# Altium schematic sheet Battery Manager.SchDoc
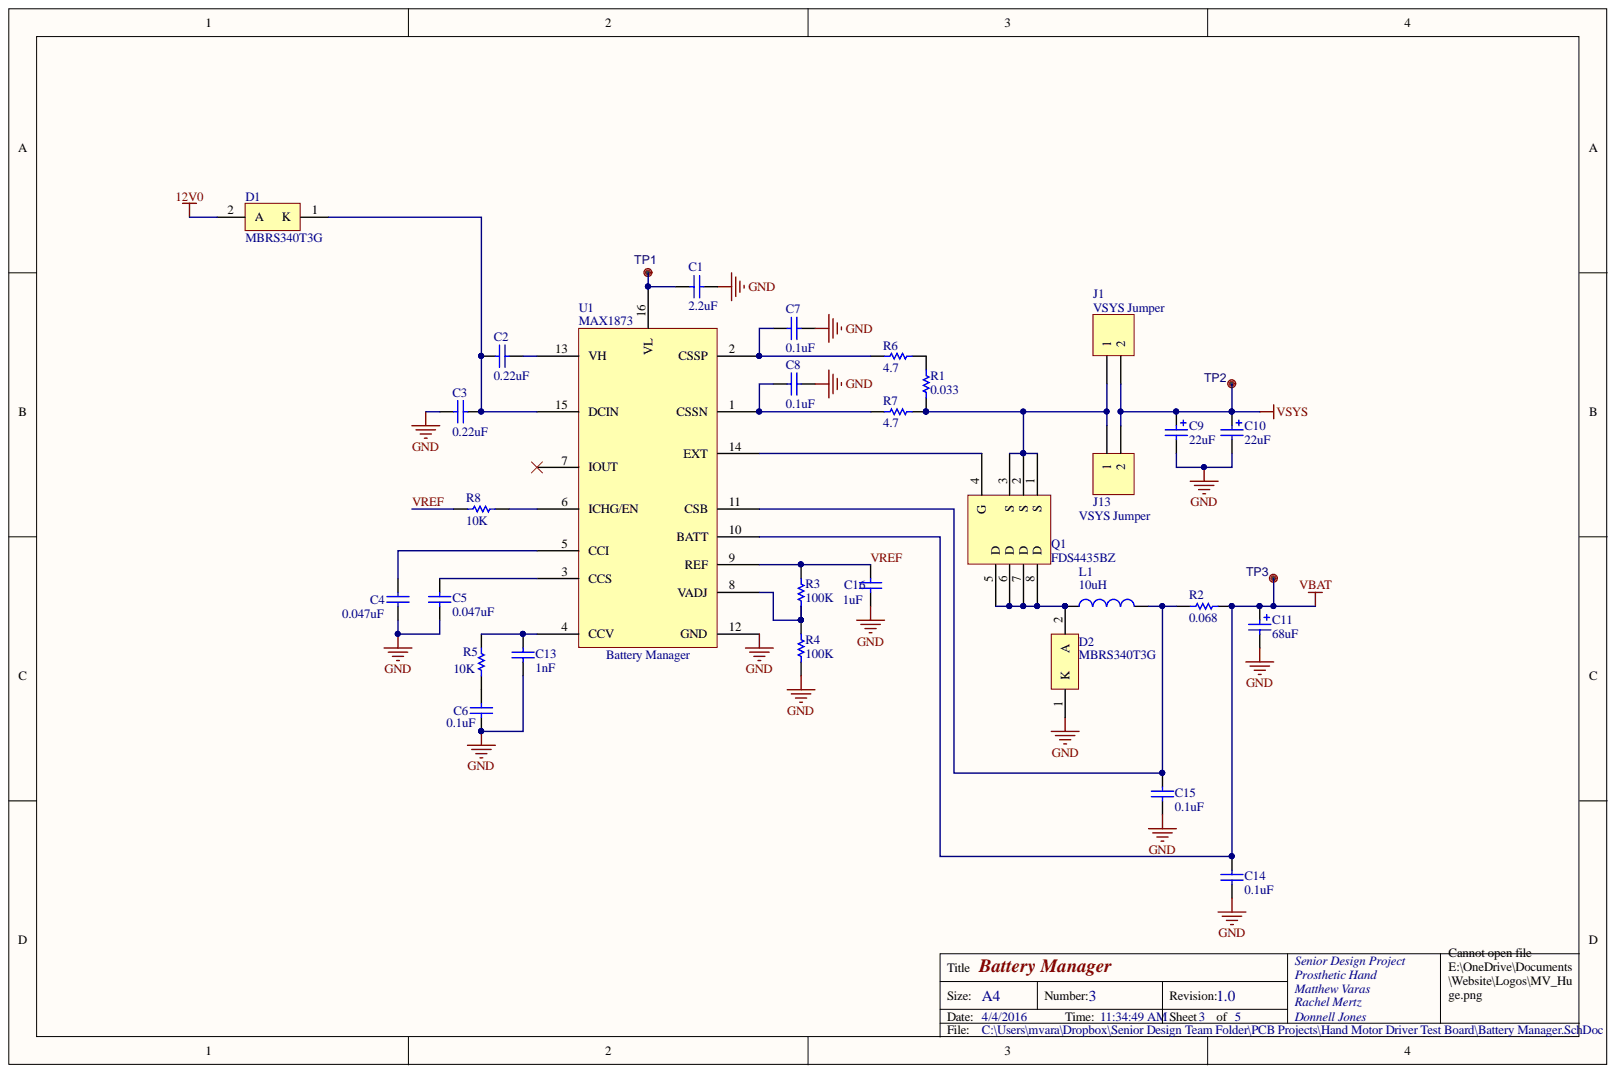
[diagram p0: Battery Manager.SchDoc]

## Parts
C1  2.2uF  [CAPC0805(2012)145_N]
C2  0.22uF  [CAPC0805(2012)145_N]
C3  0.22uF  [CAPC0805(2012)145_N]
C4  0.047uF  [CAPC0805(2012)75_N]
C5  0.047uF  [CAPC0805(2012)75_N]
C6  0.1uF  [CAPC0805(2012)145_N]
C7  0.1uF  [CAPC0805(2012)145_N]
C8  0.1uF  [CAPC0805(2012)145_N]
C9  22uF  [Cap Tant D]
C10  22uF  [Cap Tant D]
C11  68uF  [Cap Tant E]
C13  1nF  [CAPC0603(1608)100_N]
C14  0.1uF  [CAPC0805(2012)145_N]
C15  0.1uF  [CAPC0805(2012)145_N]
C16  1uF  [CAPC0805(2012)100_N]
D1  MBRS340T3G  [ONSC-SMC-2-403-03_V]
D2  MBRS340T3G  [ONSC-SMC-2-403-03_V]
J1  VSYS Jumper  [HDR1X2]
J13  VSYS Jumper  [HDR1X2]
L1  10uH  [SRR1260 Ind]
Q1  FDS4435BZ  [SOIC-8]
R1  0.033  [RESC2010(5025)_N]
R2  0.068  [WSL2816]
R3  100K  [RESC0805(2012)_N]
R4  100K  [RESC0805(2012)_N]
R5  10K  [RESC0805(2012)_N]
R6  4.7  [RESC0603(1608)_N]
R7  4.7  [RESC0603(1608)_N]
R8  10K  [RESC0805(2012)_N]
TP1  Test Point  [Testpoint_70]  (value not drawn)
TP2  Test Point  [Testpoint_70]  (value not drawn)
TP3  Test Point  [Testpoint_70]  (value not drawn)
U1  MAX1873  Desc: Battery Manager  [QSOP-16]

## Nets
12V0: D1.2
GND: C1.2 C3.2 C4.2 C5.2 C6.1 C7.1 C8.1 C9.2 C10.2 C11.2 C13.1 C14.2 C15.1 C16.1 D2.1 R4.1 U1.12(GND)
VBAT: C11.1 C14.1 R2.1 TP3.1 U1.10(BATT)
VSYS: C9.1 C10.1 J1.2 J13.2 TP2.1
VREF: C16.2 R3.2 R8.2 U1.9(REF)
NetC1_1: C1.1 TP1.1 U1.16(VL)
NetC2_1: C2.1 C3.1 D1.1 U1.15(DCIN)
NetC2_2: C2.2 U1.13(VH)
NetC4_1: C4.1 U1.5(CCI)
NetC5_1: C5.1 U1.3(CCS)
NetC6_2: C6.2 R5.2
NetC7_2: C7.2 R6.2 U1.2(CSSP)
NetC8_2: C8.2 R7.2 U1.1(CSSN)
NetC13_2: C13.2 R5.1 U1.4(CCV)
NetC15_2: C15.2 L1.2 R2.2 U1.11(CSB)
NetD2_2: D2.2 L1.1 Q1.5(D) Q1.6(D) Q1.7(D) Q1.8(D)
NetJ1_1: J1.1 J13.1 Q1.1(S) Q1.2(S) Q1.3(S) R1.1 R7.1
NetQ1_4: Q1.4(G) U1.14(EXT)
NetR1_2: R1.2 R6.1
NetR3_1: R3.1 R4.2 U1.8(VADJ)
NetR8_1: R8.1 U1.6(ICHG/EN)

Not connected: U1 7(IOUT)

## Library data of the parts
C1 = CMP-1036-04844-1: CAP CER 2.2UF 50V X5R 0805; Case-EIA: 0805; Case-Metric: 2012; DIGI_PN01: 445-14407-1-ND; MFG_NAME01: TDK; MFG_PN01: C2012X5R1H225K085AB; Tolerance: Â±10%; Component Kind: Standard; Library Name: Altium Content Vault; Max Thickness: 1.45 mm; Rated Voltage: 50 V; Technology: SMT; Value: 2.2uF
C2 C3 = CMP-1036-04552-1: CAP CER 0.22UF 35V X5R 0805; Case-EIA: 0805; Case-Metric: 2012; DIGI_PN01: 587-3366-1-ND; MFG_NAME01: Taiyo Yuden; MFG_PN01: GMK212BJ224KG; Rated Voltage: 50 V; Tolerance: Â±10%; Value: 220nF; Component Kind: Standard; Library Name: Altium Content Vault; Max Thickness: 1.45 mm; Technology: SMT
C4 C5 = CMP-1036-04259-1: CAP CER 0.047UF 50V X7R 0805; Case-EIA: 0805; Case-Metric: 2012; DIGI_PN01: 490-8050-1-ND; MFG_NAME01: Murata; MFG_PN01: GCM21BR71H473KA37L; Tolerance: Â±10%; Value: 47nF; Component Kind: Standard; Library Name: Altium Content Vault; Max Thickness: 0.75 mm; Rated Voltage: 50 V; Technology: SMT
C6-C8 C14 C15 = CMP-1036-04419-1: CAP CER 0.1UF 50V X7R 0805; Case-EIA: 0805; Case-Metric: 2012; DIGI_PN01: 445-6045-1-ND; MFG_NAME01: TDK; MFG_PN01: C2012X7R1H104K125AM; Tolerance: Â±10%; Value: 100nF; Component Kind: Standard; Library Name: Altium Content Vault; Max Thickness: 1.45 mm; Rated Voltage: 50 V; Technology: SMT
C9 C10 = Cap Pol2: CAP TANT 22UF 35V 10% 2917; Case-EIA: 2917; DIGI_PN01: 478-3356-1-ND; MFG_NAME01: AVX; MFG_PN01: TPSD226K035R0200; Component Kind: Standard; Library Name: Miscellaneous Devices.SchLib; Signal Integrity: Cap Pol; Simulation: CAP; Value: 22uF
C11 = Cap Pol2: CAP TANT 68UF 25V 20% 2917; Case-EIA: 2917; DIGI_PN01: 478-4152-1-ND; MFG_NAME01: AVX; MFG_PN01: TPSE686M025R0125; Component Kind: Standard; Library Name: Miscellaneous Devices.SchLib; Signal Integrity: Cap Pol; Simulation: CAP; Value: 68uF
C13 = CMP-1035-01889-1: CAP CER 1000PF 50V X7R 0603; Case-EIA: 0603; Case-Metric: 1608; DIGI_PN01: 490-1494-1-ND; MFG_NAME01: Murata; MFG_PN01: GRM188R71H102KA01D; Tolerance: Â±10%; Component Kind: Standard; Library Name: Altium Content Vault; Max Thickness: 1 mm; Rated Voltage: 50 V; Technology: SMT; Value: 1nF
C16 = CMP-1036-04737-1: CAP CER 1UF 25V X7R 0805; Case-EIA: 0805; Case-Metric: 2012; DIGI_PN01: 445-1354-1-ND; MFG_NAME01: TDK; MFG_PN01: C2012X7R1E105K125AB; Rated Voltage: 10 V; Tolerance: Â±10%; Component Kind: Standard; Library Name: Altium Content Vault; Max Thickness: 1 mm; Technology: SMT; Value: 1uF
D1 D2 = CMP-1055-00380-1: DIODE SCHOTTKY 40V 4A SMC; Manufacturer: On Semiconductor; Case-EIA: DO-214AB SMC; Configuration: Single; DIGI_PN01: MBRS340T3GOSCT-ND; IFSM Max (A): 80; Packing: Tape and Reel; Component Kind: Standard; ComponentLink1Description: Manufacturer URL; ComponentLink1URL: http://www.onsemi.com/; ComponentLink2Description: Datasheet; ComponentLink2URL: http://www.onsemi.cn/pub_link/Collateral/MBRS340T3-D.PDF; ComponentLink3Description: Package Specification; ComponentLink3URL: http://www.onsemi.com/pub_link/Collateral/403-03.PDF; DatasheetVersion: Rev. 11; Library Name: Altium Content Vault; MFG_NAME01: ON Semiconductor; MFG_PN01: MBRS340T3G; Mounting Technology: Surface Mount; PackageDescription: 2-Pin Small Molded Package, J-Bend Lead, Body 5.845 x 6.855 mm; PackageReference: SMC-2-403-03; PackageVersion: Rev. E, 08/2005; PartNumber: MBRS340T3G; RoHS: TRUE
J1 J13 = Header 2: CONN HEADER .100 SINGL STR 2POS; DIGI_PN01: S1012E-02-ND; MFG_NAME01: Sullins Connector Solutions; MFG_PN01: PEC02SAAN; Component Kind: Standard; LatestRevisionDate: 17-Jul-2002; LatestRevisionNote: Re-released for DXP Platform.; Library Name: Miscellaneous Connectors.SchLib; Signal Integrity: Connector; Publisher: Altium Limited
L1 = SRR1260A-100MCT-ND: FIXED IND 10UH 5.5A 20 MOHM SMD; MFG_NAME01: Bourns; Component Kind: Standard; Current Rating: 5.5A; DIGI_PN01: SRR1260A-100MCT-ND; MFG_PN01: SRR1260A-100M; Signal Integrity: Inductor; Simulation: INDUCTOR; Value: 10uH; Library Name: Prosthetic Hand Schematic Lib.SchLib
Q1 = FDS4435BZ: MOSFET P-CH 30V 8.8A 8-SOIC; DIGI_PN01: FDS4435BZCT-ND; MFG_NAME01: Fairchild Semiconductor; Case-EIA: 8-SOIC; Component Kind: Standard; MFG_PN01: FDS4435BZ; Library Name: Prosthetic Hand Schematic Lib.SchLib
R1 = CMP-1017-00002-1: RES SMD 0.033 OHM 1% 1W 2010; Case-EIA: 2010; Case-Metric: 5025; DIGI_PN01: WSLF-.033CT-ND; MFG_NAME01: Murata; MFG_PN01: WSL2010R0330FEA18; Component Kind: Standard; Library Name: Altium Content Vault; Power: 1W; Technology: SMT; Tolerance: 1%; Value: 0.033
R2 = CMP-1017-00002-1: RES SMD 0.068 OHM 1% 2W 2816; Case-EIA: 2816; Case-Metric: 5025; DIGI_PN01: WSLK-.068CT-ND; MFG_NAME01: Vishay Dale; MFG_PN01: WSL2816R0680FEH; Component Kind: Standard; Power: 2W; Technology: SMT; Tolerance: 1%; Value: 0.068
R3 R4 = CMP-1013-00736-1: RES SMD 100K OHM 1% 1/8W 0805; Case-EIA: 0805; Case-Metric: 2012; DIGI_PN01: 311-100KCRCT-ND; MFG_NAME01: Yageo; MFG_PN01: RC0805FR-07100KL; Power: 0.125 W; Component Kind: Standard; Library Name: Altium Content Vault; Technology: SMT; Tolerance: 1 %; Value: 100K
R5 R8 = CMP-1013-00623-1: RES SMD 10K OHM 1% 1/8W 0805; Case-EIA: 0805; Case-Metric: 2012; DIGI_PN01: 311-10.0KCRCT-ND; MFG_NAME01: Yageo; MFG_PN01: RC0805FR-0710KL; Power: 0.125 W; Component Kind: Standard; Library Name: Altium Content Vault; Technology: SMT; Tolerance: 1 %; Value: 10K
R6 R7 = CMP-1012-00247-1: RES SMD 4.7 OHM 1% 1/10W 0603; Case-EIA: 0603; Case-Metric: 1608; DIGI_PN01: 1276-4486-1-ND; MFG_NAME01: Samsung; MFG_PN01: RC1608F4R7CS; Power: 0.1 W; Value: 4.70 Ohm; Component Kind: Standard; Library Name: Altium Content Vault; Technology: SMT; Tolerance: 1 %
TP1-TP3 = Test Point: Test Pad; MFG_NAME01: DNP; Component Kind: Standard; DNP: DNP; MFG_PN01: DNP; Library Name: Prosthetic Hand Schematic Lib.SchLib
U1 = MAX1873: IC CNTRLR CHARGE LI+ 16-QSOP; Case-EIA: 16-SSOP; DIGI_PN01: MAX1873REEE+TCT-ND; MFG_NAME01: Maxim Integrated; MFG_PN01: MAX1873REEE+T; Component Kind: Standard; Library Name: Prosthetic Hand Schematic Lib.SchLib
